# Altium schematic sheet Power_Management.SchDoc
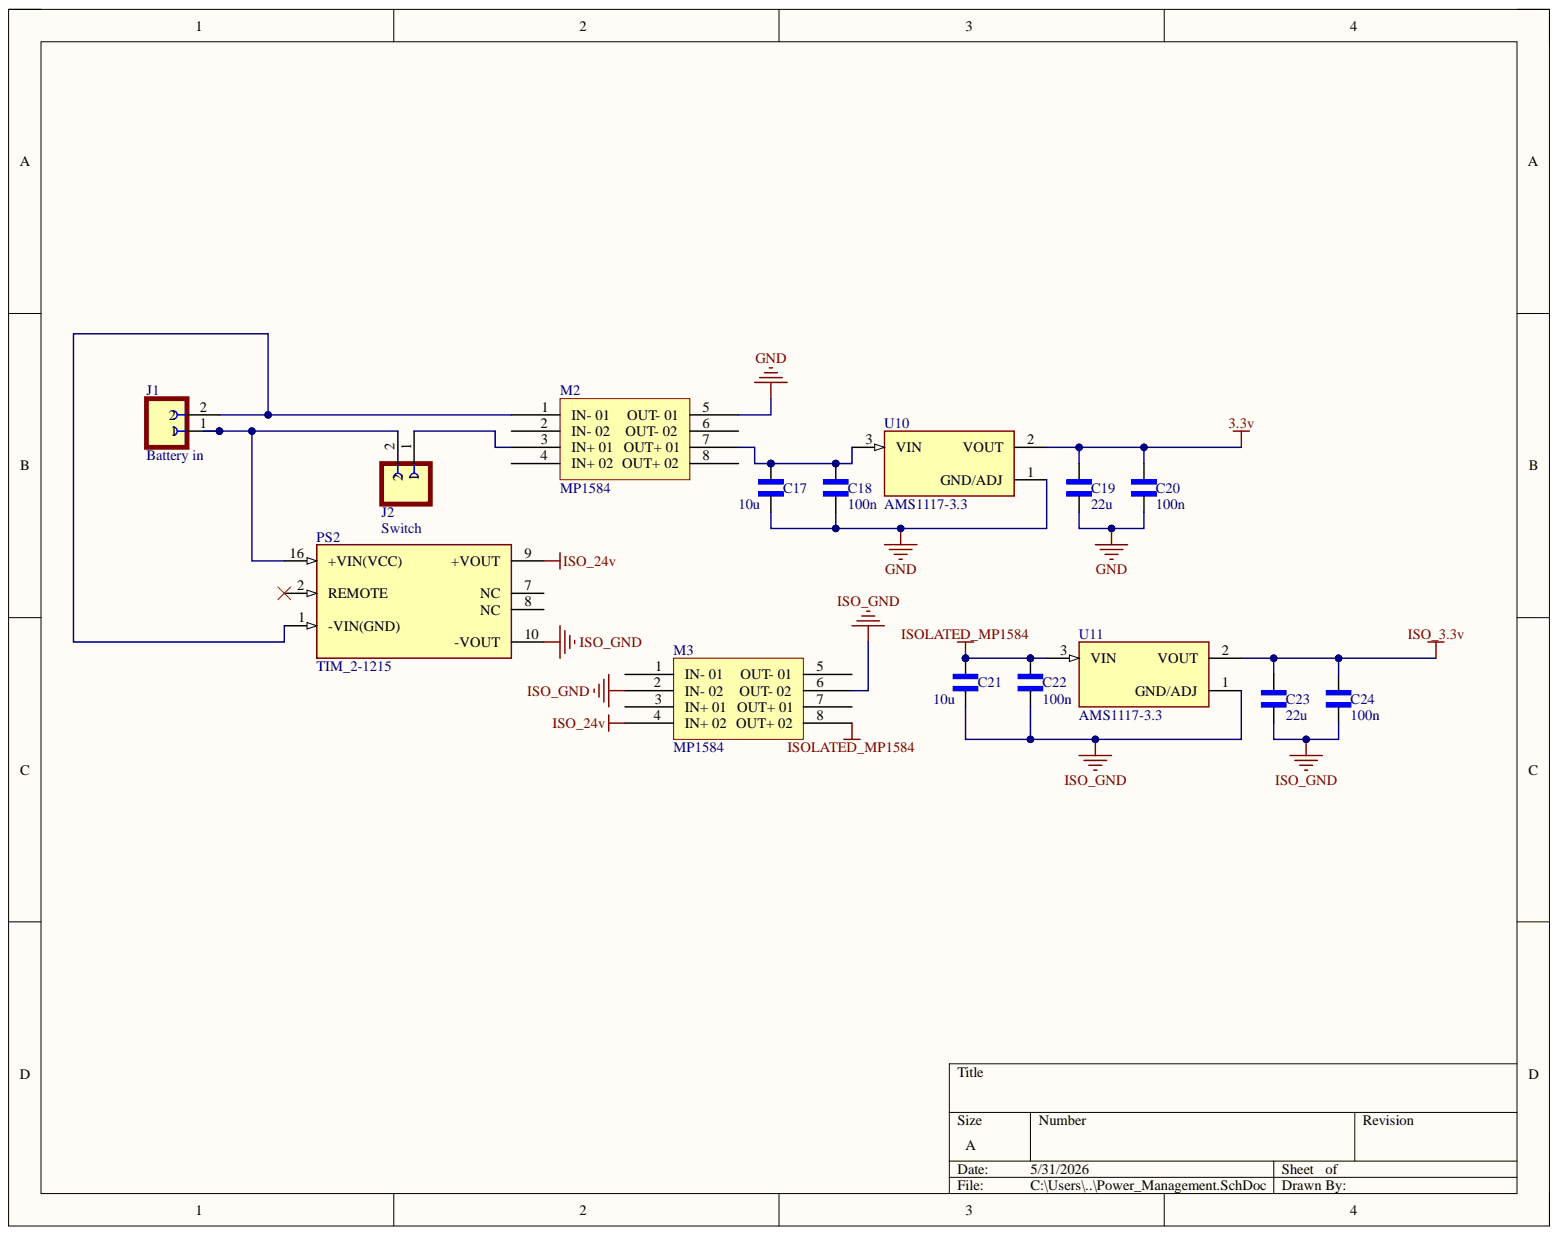
[diagram p0: Power_Management.SchDoc]

## Parts
C17  10u  [RESC3216X125N]
C18  100n  [CAPC1608X90]
C19  22u  [RESC3216X125N]
C20  100n  [CAPC1608X90]
C21  10u  [RESC3216X125N]
C22  100n  [CAPC1608X90]
C23  22u  [RESC3216X125N]
C24  100n  [CAPC1608X90]
J1  Battery in  [JST_PHR-2]
J2  Switch  [JST_PHR-2]
M2  MP1584  [PCBComponent_1]
M3  MP1584  [PCBComponent_1]
PS2  TIM_2-1215  [CONV_TIM_2-1215]
U10  AMS1117-3.3  [SOT229P700X180-4N]
U11  AMS1117-3.3  [SOT229P700X180-4N]

## Nets
3.3v: C19.2 C20.1 U10.2
GND: C17.1 C18.2 C19.1 C20.2 M2.5(OUT-_01) U10.1
ISO_3.3v: C23.2 C24.1 U11.2
ISO_24v: M3.4(IN+_02) PS2.9(+VOUT)
ISO_GND: C21.1 C22.2 C23.1 C24.2 M3.2(IN-_02) M3.6(OUT-_02) PS2.10(-VOUT) U11.1
ISOLATED_MP1584: C21.2 C22.1 M3.8(OUT+_02) U11.3
NetC17_2: C17.2 C18.1 M2.7(OUT+_01) U10.3
NetJ1_1: J1.1 J2.2 PS2.16(+VIN(VCC))
NetJ1_2: J1.2 M2.1(IN-_01) PS2.1(-VIN(GND))
NetJ2_1: J2.1 M2.3(IN+_01)

Not connected: M2 2(IN-_02) 4(IN+_02) 6(OUT-_02) 8(OUT+_02); M3 1(IN-_01) 3(IN+_01) 5(OUT-_01) 7(OUT+_01); PS2 2(REMOTE) 7(NC) 8(NC)

## Library data of the parts
C17 C19 C21 C23 = T491A106K016AT: Capacitors Tantalum PCB 10uF SMD Tol 10% 16 VDC Case Size 3216 T491 Series | KEMET T491A106K016AT; Check_prices: https://www.snapeda.com/parts/T491A106K016AT/KEMET/view-part/?ref=eda; Component Kind: Standard; Library Name: T491A106K016AT.IntLib; MF: KEMET; MP: T491A106K016AT; Package: SMD-2 KEMET; SnapEDA_Link: https://www.snapeda.com/parts/T491A106K016AT/KEMET/view-part/?ref=snap
C18 C20 C22 C24 = C0603KRX7R9BB102: 0603 1 nF 50 V Â±10 % Tolerance X7R Surface Mount Ceramic Capacitor; MF: Yageo; Check_prices: https://www.snapeda.com/parts/C0603KRX7R9BB102/Yageo/view-part/?ref=eda; Component Kind: Standard; Library Name: C0603KRX7R9BB102.IntLib; MP: C0603KRX7R9BB102; Package: 0603 Yageo; SnapEDA_Link: https://www.snapeda.com/parts/C0603KRX7R9BB102/Yageo/view-part/?ref=snap
J1 J2 = PHR-2: PH Series 2 Position 2 mm Pitch Free Hanging Housing Connector; Availability: Unavailable; Component Kind: Standard; Library Name: PHR-2.IntLib; MP: PHR-2; Package: None; Price: None
M2 M3 = Component_1: Component Kind: Standard; Library Name: MP1584.IntLib
U10 U11 = AMS1117-3.3: Sot223/Pkg 1-Amp 3.3-Volt Low Drop Out Voltage Regulatator; MF: Advanced Monolithic Systems; Check_prices: https://www.snapeda.com/parts/AMS1117-3.3/Advanced+Monolithic+Systems/view-part/?ref=eda; Component Kind: Standard; Library Name: AMS1117-3.3.IntLib; MP: AMS1117-3.3; Package: SOT-223 Seeed Technology; SnapEDA_Link: https://www.snapeda.com/parts/AMS1117-3.3/Advanced+Monolithic+Systems/view-part/?ref=snap
PS2 = TIM_2-1215: Availability: Unavailable; Component Kind: Standard; Library Name: TIM_2-1215.IntLib; MP: TIM_2-1215; Package: None; Price: None
